# Altium schematic sheet Sheet4.SchDoc(Sheet4)
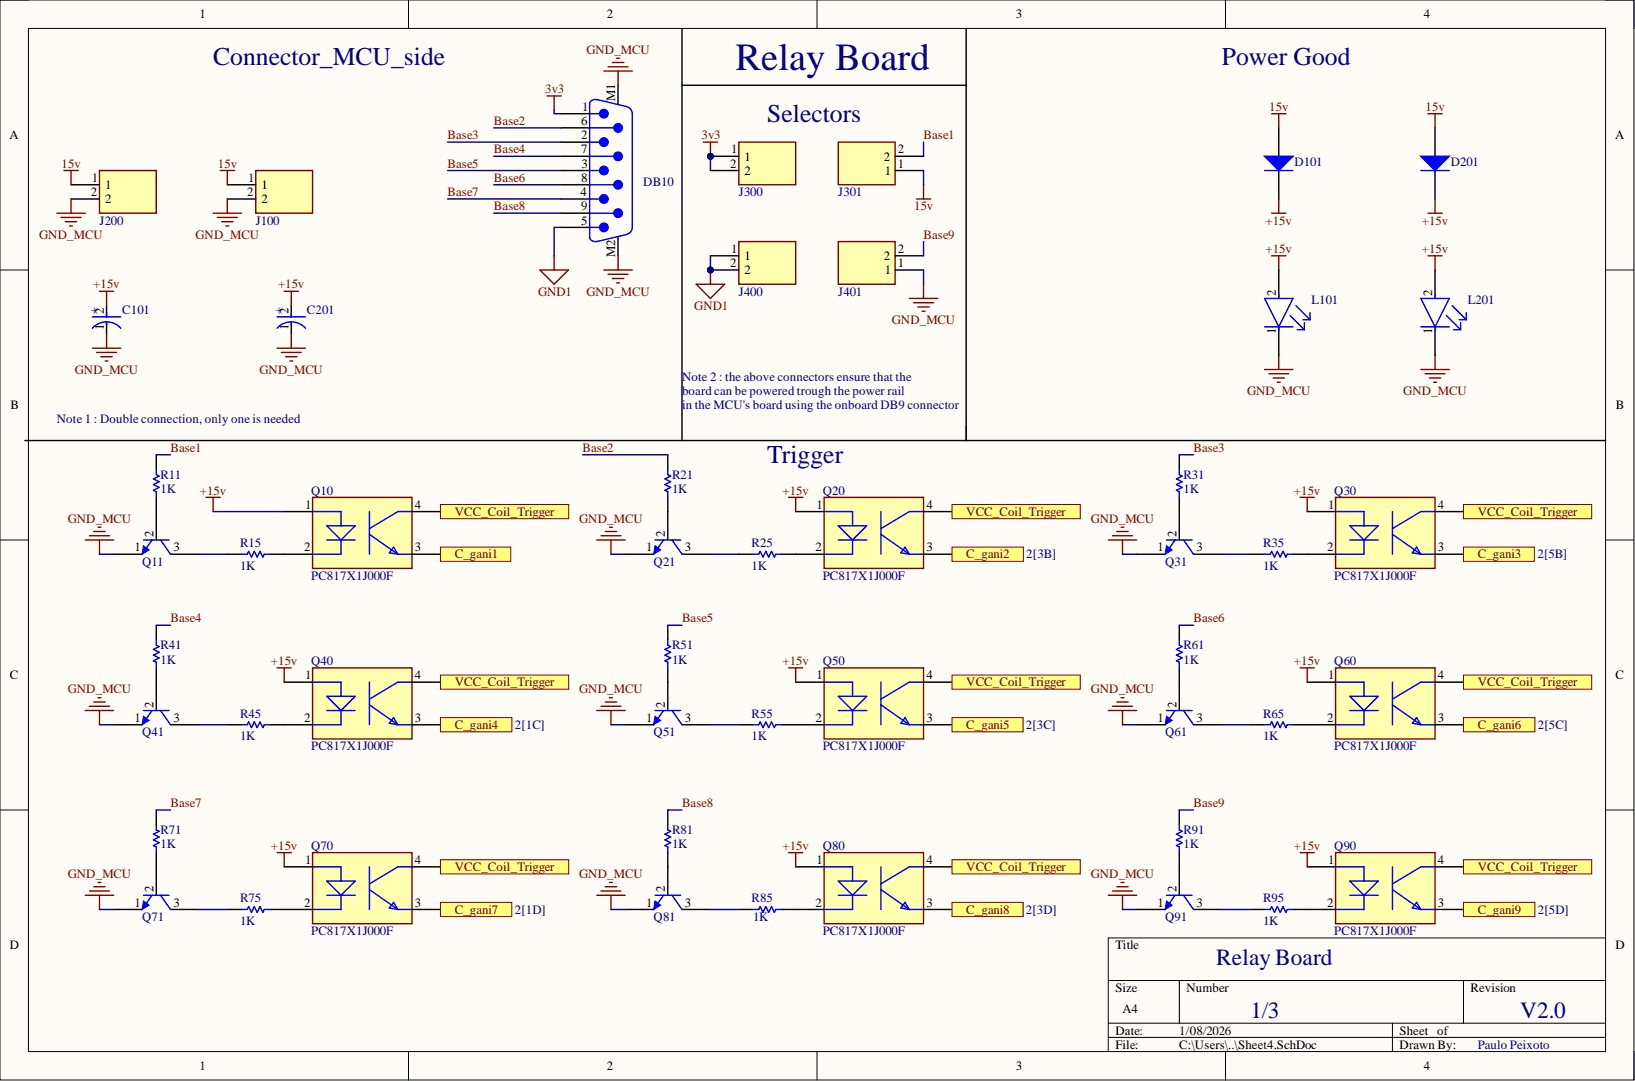
[diagram p0: Sheet4.SchDoc]

## Parts
C101  EEE-FK1H100UR  [FP-FKC-MFG]  (value not drawn)
C201  EEE-FK1H100UR  [FP-FKC-MFG]  (value not drawn)
D101  1N4001-T  [DIOD-DO-41-P-2_V]  (value not drawn)
D201  1N4001-T  [DIOD-DO-41-P-2_V]  (value not drawn)
DB10  618009231221  [618009231221]  (value not drawn)
J100  TB004-508-02BE  [Terminal_TH_2P_3.50]  (value not drawn)
J200  TB004-508-02BE  [Terminal_TH_2P_3.50]  (value not drawn)
J300  TB004-508-02BE  [HEADER_MALE_TH_2P_2.54]  (value not drawn)
J301  TB004-508-02BE  [HEADER_MALE_TH_2P_2.54]  (value not drawn)
J400  TB004-508-02BE  [HEADER_MALE_TH_2P_2.54]  (value not drawn)
J401  TB004-508-02BE  [HEADER_MALE_TH_2P_2.54]  (value not drawn)
L101  TLLG4400  [FP-6_544-5255_01-4-MFG]  (value not drawn)
L201  TLLG4400  [FP-6_544-5255_01-4-MFG]  (value not drawn)
Q10  PC817X1J000F  [FP-PC817X1J000F-MFG]
Q11  PN2222ATF  [FAIR-TO-92-3]  (value not drawn)
Q20  PC817X1J000F  [FP-PC817X1J000F-MFG]
Q21  PN2222ATF  [FAIR-TO-92-3]  (value not drawn)
Q30  PC817X1J000F  [FP-PC817X1J000F-MFG]
Q31  PN2222ATF  [FAIR-TO-92-3]  (value not drawn)
Q40  PC817X1J000F  [FP-PC817X1J000F-MFG]
Q41  PN2222ATF  [FAIR-TO-92-3]  (value not drawn)
Q50  PC817X1J000F  [FP-PC817X1J000F-MFG]
Q51  PN2222ATF  [FAIR-TO-92-3]  (value not drawn)
Q60  PC817X1J000F  [FP-PC817X1J000F-MFG]
Q61  PN2222ATF  [FAIR-TO-92-3]  (value not drawn)
Q70  PC817X1J000F  [FP-PC817X1J000F-MFG]
Q71  PN2222ATF  [FAIR-TO-92-3]  (value not drawn)
Q80  PC817X1J000F  [FP-PC817X1J000F-MFG]
Q81  PN2222ATF  [FAIR-TO-92-3]  (value not drawn)
Q90  PC817X1J000F  [FP-PC817X1J000F-MFG]
Q91  PN2222ATF  [FAIR-TO-92-3]  (value not drawn)
R11  1K  [RESC3216X70X50NL05T20]
R15  1K  [RESC3216X70X50NL05T20]
R21  1K  [RESC3216X70X50NL05T20]
R25  1K  [RESC3216X70X50NL05T20]
R31  1K  [RESC3216X70X50NL05T20]
R35  1K  [RESC3216X70X50NL05T20]
R41  1K  [RESC3216X70X50NL05T20]
R45  1K  [RESC3216X70X50NL05T20]
R51  1K  [RESC3216X70X50NL05T20]
R55  1K  [RESC3216X70X50NL05T20]
R61  1K  [RESC3216X70X50NL05T20]
R65  1K  [RESC3216X70X50NL05T20]
R71  1K  [RESC3216X70X50NL05T20]
R75  1K  [RESC3216X70X50NL05T20]
R81  1K  [RESC3216X70X50NL05T20]
R85  1K  [RESC3216X70X50NL05T20]
R91  1K  [RESC3216X70X50NL05T20]
R95  1K  [RESC3216X70X50NL05T20]

## Ports
C_gani1, C_gani2, C_gani3, C_gani4, C_gani5, C_gani6, C_gani7, C_gani8, C_gani9, VCC_Coil_Trigger

## Nets
3v3: DB10.1 J300.1 J300.2
15v: C101.2 C201.2 D101.1 D101.2 D201.1 D201.2 J100.1 J200.1 J301.1 L101.2 L201.2 Q10.1 Q20.1 Q30.1 Q40.1 Q50.1 Q60.1 Q70.1 Q80.1 Q90.1
GND1: DB10.5 J400.1 J400.2
GND_MCU: C101.1 C201.1 DB10.M1 DB10.M2 J100.2 J200.2 J401.1 L101.1 L201.1 Q11.1 Q21.1 Q31.1 Q41.1 Q51.1 Q61.1 Q71.1 Q81.1 Q91.1
Base1: J301.2 R11.2
Base2: DB10.6 R21.2
Base3: DB10.2 R31.2
Base4: DB10.7 R41.2
Base5: DB10.3 R51.2
Base6: DB10.8 R61.2
Base7: DB10.4 R71.2
Base8: DB10.9 R81.2
Base9: J401.2 R91.2
C_gani1: Q10.3 ; port C_gani1
C_gani2: Q20.3 ; port C_gani2
C_gani3: Q30.3 ; port C_gani3
C_gani4: Q40.3 ; port C_gani4
C_gani5: Q50.3 ; port C_gani5
C_gani6: Q60.3 ; port C_gani6
C_gani7: Q70.3 ; port C_gani7
C_gani8: Q80.3 ; port C_gani8
C_gani9: Q90.3 ; port C_gani9
VCC_Coil_Trigger: Q10.4 Q20.4 Q30.4 Q40.4 Q50.4 Q60.4 Q70.4 Q80.4 Q90.4 ; port VCC_Coil_Trigger
NetQ10_2: Q10.2 R15.1
NetQ11_2: Q11.2 R11.1
NetQ11_3: Q11.3 R15.2
NetQ20_2: Q20.2 R25.1
NetQ21_2: Q21.2 R21.1
NetQ21_3: Q21.3 R25.2
NetQ30_2: Q30.2 R35.1
NetQ31_2: Q31.2 R31.1
NetQ31_3: Q31.3 R35.2
NetQ40_2: Q40.2 R45.1
NetQ41_2: Q41.2 R41.1
NetQ41_3: Q41.3 R45.2
NetQ50_2: Q50.2 R55.1
NetQ51_2: Q51.2 R51.1
NetQ51_3: Q51.3 R55.2
NetQ60_2: Q60.2 R65.1
NetQ61_2: Q61.2 R61.1
NetQ61_3: Q61.3 R65.2
NetQ70_2: Q70.2 R75.1
NetQ71_2: Q71.2 R71.1
NetQ71_3: Q71.3 R75.2
NetQ80_2: Q80.2 R85.1
NetQ81_2: Q81.2 R81.1
NetQ81_3: Q81.3 R85.2
NetQ90_2: Q90.2 R95.1
NetQ91_2: Q91.2 R91.1
NetQ91_3: Q91.3 R95.2

## Library data of the parts
C101 C201 = CMP-05427-000030-1: CAP ALUM 10UF 20% 50V SMD; Dielectric Material: Aluminium; ESR (Equivalent Series Resistance): 1.52R; Leakage Current: 3uA; Life (Hours): 2000Hour; Ripple Current: 85mA; Capacitance: 10uF; Case/Package: Radial; Component Kind: Standard; Construction: Cylindrical; Contact Plating: Tin; Diameter: 5mm; Height: 5.8mm; Height - Seated (Max): 0.228inch; Impedance: 1.52R; Lead Free: Lead Free; Length: 5.8mm; Library Name: Altium Content Vault; Material: Aluminium; Max Operating Temperature: 105°C; Min Operating Temperature: -55°C; Mount: Surface Mount; Number of Pins: 2; Package Quantity: 1000; Packaging: Tape and Reel; Radiation Hardening: No; REACH SVHC: No SVHC; Ripple Current (AC): 85mA; RoHS Compliant: Yes; Series: FK; Termination: SMD/SMT; Tolerance: 20%; Voltage: 50V; Voltage Rating: 50V; Voltage Rating (DC): 50V; Width: 6.5mm
D101 D201 = CMP-0639-00006-2: 1.0 A Rectifier, 15 pF, 50 V, -65 to 150 degC, 2-Pin DO-41 Plastic, RoHS, Tape and Reel; Manufacturer: Diodes Inc; CT (pF): 15; Max. Average Rectified Current IO (A): 1; Max. IFSM (A): 30; Max. IR (uA): 5; VRRM (V): 50; Component Kind: Standard; ComponentLink1Description: Manufacturer URL; ComponentLink1URL: http://diodes.com/; ComponentLink2Description: Datasheet; ComponentLink2URL: http://diodes.com/datasheets/ds28002.pdf; DatasheetVersion: Rev. 8-2; Library Name: Altium Content Vault; Mounting Technology: Through Hole; Operating Temperature Range (degC): -65 to 150; PackageDescription: 2-Pin Axial Lead, Body 4.635 x 2.36 mm, Lead Spread 25.4 mm; PackageReference: DO-41-P-2; Packing: Tape and Reel; PartNumber: 1N4001-T; RoHS: TRUE; VF (V): 1
DB10 = CMP-1502-00284-2: NexarPartId: 22865742; Contact Resistance: 30mΩ; Current Rating: 3A; Gender: Male; Insulation Resistance: 5GΩ; Number of Contacts: 9; Operating Supply Voltage: 125V; Pitch: 2.77mm; Component Kind: Standard; Contact Material: Gold; Contact Plating: Gold; Depth: 18.5mm; Height: 12.7mm; Housing Material: Steel; Insulation Material: PBT; Length: 30.8mm; Library Name: Altium Content Vault; Max Operating Temperature: 105°C; Min Operating Temperature: -55°C; Mount: Through Hole; Number of Pins: 9; Number of Rows: 2; Orientation: Right Angle; REACH SVHC: Unknown; RoHS: Compliant; Termination: SMD/SMT; Voltage Rating: 125V; Width: 12.5mm
J100 J200 = Con_Terminal_2P: Connector; Manufacturer_Name: CUI Devices; Arrow Part Number: TB004-508-02BE; Arrow Price/Stock: https://www.arrow.com/en/products/tb004-508-02be/cui-inc; Component Kind: Standard; Datasheet Link: https://componentsearchengine.com/Datasheets/1/TB004-508-02BE.pdf; Height: 14.1mm; Manufacturer_Part_Number: TB004-508-02BE; Mouser Part Number: 490-TB004-508-02BE; Mouser Price/Stock: https://www.mouser.co.uk/ProductDetail/CUI-Devices/TB004-508-02BE?qs=vLWxofP3U2zLwdGzG2tSkA%3D%3D; Library Name: GEPE_SchLib_Altium.SchLib
J300 J301 J400 J401 = Con_Header_2P: Connector; Manufacturer_Name: CUI Devices; Arrow Part Number: TB004-508-02BE; Arrow Price/Stock: https://www.arrow.com/en/products/tb004-508-02be/cui-inc; Component Kind: Standard; Datasheet Link: https://componentsearchengine.com/Datasheets/1/TB004-508-02BE.pdf; Height: 14.1mm; Manufacturer_Part_Number: TB004-508-02BE; Mouser Part Number: 490-TB004-508-02BE; Mouser Price/Stock: https://www.mouser.co.uk/ProductDetail/CUI-Devices/TB004-508-02BE?qs=vLWxofP3U2zLwdGzG2tSkA%3D%3D; Library Name: GEPE_SchLib_Altium.SchLib
L101 L201 = CMP-00030-55433990-1: TLLG4400 Led, Pcb, Green, 0.63MCD, 3MM, T-1, 7MA, 1.9V, 565NM, 25DEG, 20V, 20MW, -40; Dominant Wavelength: 575nm; Forward Current: 2mA; Lens Style: Circular, Colored, Diffused, Dome, Round; Lens Transparency: Diffused; Luminous Intensity: 1.2mcd; Number of Elements: 1; Viewing Angle: 50°; Voltage Rating (DC): 2.4V; Case/Package: T; Color: Green; Component Kind: Standard; Depth: 3.2mm; Forward Voltage: 1.9V; Height: 4.5mm; Illumination Color: Green; Length: 3.2mm; Lens Color: Green; Lens Size: 3mm; Library Name: Altium Content Vault; Max Operating Temperature: 100°C; Max Power Dissipation: 20mW; Min Operating Temperature: -40°C; Mount: Through Hole; NexarPartId: 55433990; Number of LEDs: 1; Number of Pins: 2; Power Dissipation: 20mW; Radiation Hardening: No; REACH SVHC: Unknown; Reverse Voltage: 20V; RoHS: Compliant; Schedule B: 8541402000, 8541402000; Test Current: 2mA; Wavelength: 575nm
Q10 Q20 Q30 Q40 Q50 Q60 Q70 Q80 Q90 = CMP-65434-000003-1: OPTOISOLATOR 5KV TRANS 4DIP; Collector Emitter Breakdown Voltage: 80V; Collector Emitter Saturation Voltage: 200mV; Current Transfer Ratio: 600%; Fall Time: 18000ns; Forward Current: 50mA; Forward Voltage: 1.4V; Input Current: 20mA; Max Power Dissipation: 200mW; Number of Channels: 1; Reverse Breakdown Voltage: 6V; Case/Package: DIP; Collector Emitter Voltage (VCEO): 80V; Component Kind: Standard; Isolation Voltage: 5kV; Library Name: Altium Content Vault; Max Collector Current: 50mA; Max Input Current: 50mA; Max Operating Temperature: 100°C; Min Operating Temperature: -30°C; Mount: Through Hole; Number of Elements: 1; Number of Pins: 4; Output Current per Channel: 50mA; Output Voltage: 80V; Package Quantity: 2000; Packaging: Sleeve; Power Dissipation: 200mW; Radiation Hardening: No; Rise Time: 18000ns
Q11 Q21 Q31 Q41 Q51 Q61 Q71 Q81 Q91 = CMP-2000-06911-1: NPN General-Purpose Amplifier, 40 V, -55 to 150 degC, 3-Pin TO-92, RoHS, Tape and Reel; Brand: FairchildSemiconductor; Collector- Base Voltage VCBO: 75V; Collector- Emitter Voltage VCEO Max: 40V; Collector-Emitter Saturation Voltage: 1V; Configuration: Single; DC Collector/Base Gain hfe Min: 100; DC Current Gain hFE Max: 300; Emitter- Base Voltage VEBO: 6V; Gain Bandwidth Product fT: 300MHz; Maximum DC Collector Current: 1A; Maximum Power Dissipation: 625mW; Unit Weight: 0.008466oz; <PCN>: http://www.mouser.com/PCN/3.26.10LTBDFairchild.pdf; Component Kind: Standard; Datasheet URL: https://www.fairchildsemi.com/datasheets/PN/PN2222A.pdf; Datasheet Version: Rev. 1.1.0, 07/2014; Factory Pack Quantity: 2000; Library Name: Altium Content Vault; Manufacturer: FairchildSemiconductor; Manufacturer URL: https://www.fairchildsemi.com/; Maximum Operating Temperature: +150C; Minimum Operating Temperature: -55C; Mounting Style: ThroughHole; Mounting Technology: ThroughHole; Package / Case: TO-92-3KinkedLead; Package Description: 3-Pin Transistor Outline Package, Body Dia 4.79 mm, Pitch 2.6 mm; Package Reference: TO-92; Packaging: Reel; Product Category: BipolarTransistors-BJT; RoHS: Details; Series: PN2222; Transistor Polarity: NPN
R11 R15 R21 R25 R31 R35 R41 R45 R51 R55 R61 R65 R71 R75 R81 R85 R91 R95 = CMP-2003-00541-1: Manufacturer: Panasonic; Part Number: ERJ-8GEY0R00V; Component Kind: Standard; Library Name: Altium Content Vault
